# Altium schematic sheet High Voltage.SchDoc("High Voltage")
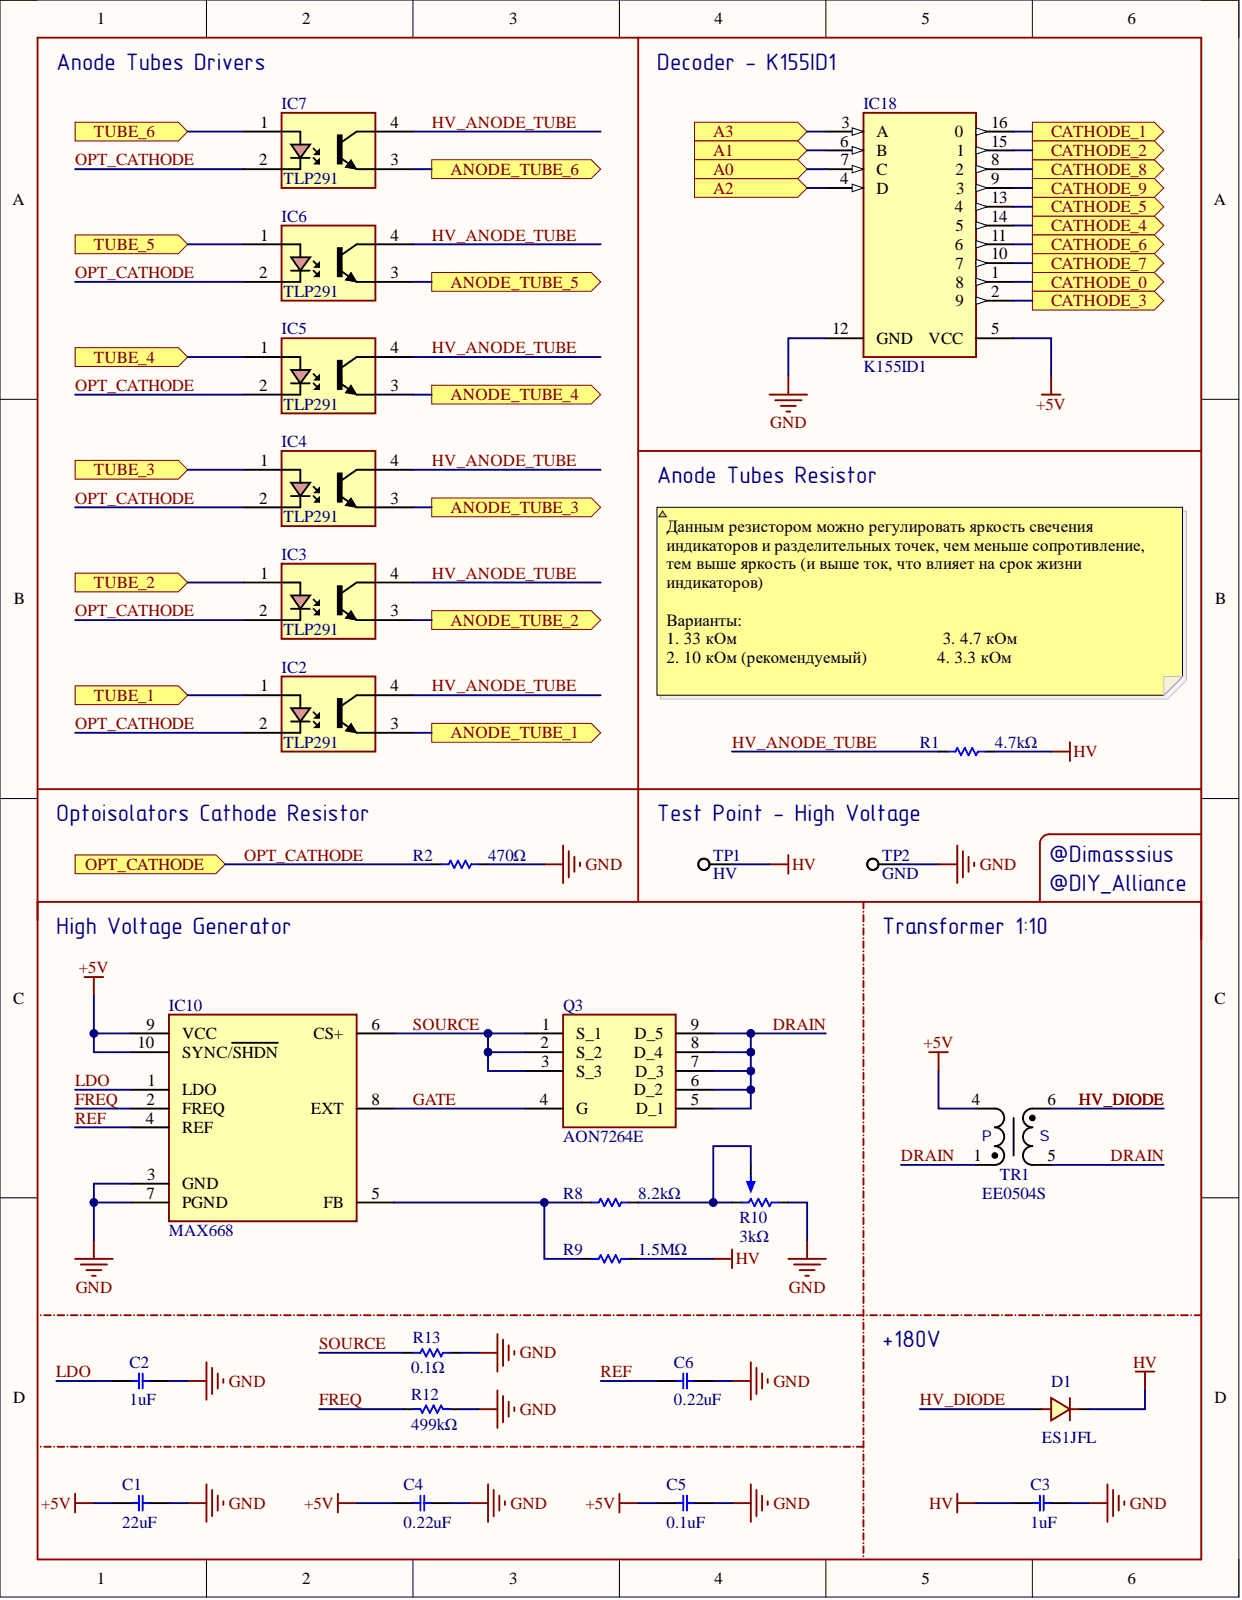
[diagram p0: High Voltage.SchDoc]

## Parts
C1  22uF  [CAP_SMD_MLCC_C0603_(M1608)_C0402A_(M1005A)]
C2  1uF  [CAP_SMD_MLCC_C0603_(M1608)_C0402A_(M1005A)]
C3  1uF  [CAP_SMD_MLCC_C1812_(M4532)]
C4  0.22uF  [CAP_SMD_MLCC_C0603_(M1608)_C0402A_(M1005A)]
C5  0.1uF  [CAP_SMD_MLCC_C0603_(M1608)_C0402A_(M1005A)]
C6  0.22uF  [CAP_SMD_MLCC_C0603_(M1608)_C0402A_(M1005A)]
D1  ES1JFL  [SODFL3719X135N]
IC2  TLP291  [SOIC127P700X230-4N]
IC3  TLP291  [SOIC127P700X230-4N]
IC4  TLP291  [SOIC127P700X230-4N]
IC5  TLP291  [SOIC127P700X230-4N]
IC6  TLP291  [SOIC127P700X230-4N]
IC7  TLP291  [SOIC127P700X230-4N]
IC10  MAX668  [SOP50P490X110-10N]
IC18  K155ID1  [DIP16]
Q3  AON7264E  [DFN-8-EP(3x3)]
R1  4.7kΩ  [RES_SMD_R0603_(M1608)_R0402A_(M1005A)]
R2  470Ω  [RES_SMD_R0603_(M1608)_R0402A_(M1005A)]
R8  8.2kΩ  [RES_SMD_R0603_(M1608)_R0402A_(M1005A)]
R9  1.5MΩ  [RES_SMD_R0603_(M1608)_R0402A_(M1005A)]
R10  3kΩ  [TC33X]
R12  499kΩ  [RES_SMD_R0603_(M1608)_R0402A_(M1005A)]
R13  0.1Ω  [RES_SMD_R1206_(M3216)]
TP1  HV  [Test Point]  (no BOM)
TP2  GND  [Test Point]  (no BOM)
TR1  EE0504S  [EE0504S]

## Ports
A0, A1, A2, A3, ANODE_TUBE_1, ANODE_TUBE_2, ANODE_TUBE_3, ANODE_TUBE_4, ANODE_TUBE_5, ANODE_TUBE_6, CATHODE_0, CATHODE_1, CATHODE_2, CATHODE_3, CATHODE_4, CATHODE_5, CATHODE_6, CATHODE_7, CATHODE_8, CATHODE_9, OPT_CATHODE, TUBE_1, TUBE_2, TUBE_3, TUBE_4, TUBE_5, TUBE_6

## Nets
+5V: C1.1 C4.2 C5.1 IC10.9(VCC) IC10.10(SYNC/SHDN) IC18.5(VCC) TR1.4
GND: C1.2 C2.2 C3.1 C4.1 C5.2 C6.1 IC10.3(GND) IC10.7(PGND) IC18.12(GND) R2.1 R10.3 R12.2 R13.1 TP2.1
HV: C3.2 D1.1 R1.2 R9.1 TP1.1
A0: IC18.7(C) ; port A0
A1: IC18.6(B) ; port A1
A2: IC18.4(D) ; port A2
A3: IC18.3(A) ; port A3
ANODE_TUBE_1: IC2.3 ; port ANODE_TUBE_1
ANODE_TUBE_2: IC3.3 ; port ANODE_TUBE_2
ANODE_TUBE_3: IC4.3 ; port ANODE_TUBE_3
ANODE_TUBE_4: IC5.3 ; port ANODE_TUBE_4
ANODE_TUBE_5: IC6.3 ; port ANODE_TUBE_5
ANODE_TUBE_6: IC7.3 ; port ANODE_TUBE_6
CATHODE_0: IC18.1 ; port CATHODE_0
CATHODE_1: IC18.16 ; port CATHODE_1
CATHODE_2: IC18.15 ; port CATHODE_2
CATHODE_3: IC18.2 ; port CATHODE_3
CATHODE_4: IC18.14 ; port CATHODE_4
CATHODE_5: IC18.13 ; port CATHODE_5
CATHODE_6: IC18.11 ; port CATHODE_6
CATHODE_7: IC18.10 ; port CATHODE_7
CATHODE_8: IC18.8 ; port CATHODE_8
CATHODE_9: IC18.9 ; port CATHODE_9
DRAIN: Q3.5(D_1) Q3.6(D_2) Q3.7(D_3) Q3.8(D_4) Q3.9(D_5) TR1.1 TR1.5
FREQ: IC10.2(FREQ) R12.1
GATE: IC10.8(EXT) Q3.4(G)
HV_ANODE_TUBE: IC2.4 IC3.4 IC4.4 IC5.4 IC6.4 IC7.4 R1.1
HV_DIODE: D1.2 TR1.6
LDO: C2.1 IC10.1(LDO)
OPT_CATHODE: IC2.2 IC3.2 IC4.2 IC5.2 IC6.2 IC7.2 R2.2 ; port OPT_CATHODE
REF: C6.2 IC10.4(REF)
SOURCE: IC10.6(CS+) Q3.1(S_1) Q3.2(S_2) Q3.3(S_3) R13.2
TUBE_1: IC2.1 ; port TUBE_1
TUBE_2: IC3.1 ; port TUBE_2
TUBE_3: IC4.1 ; port TUBE_3
TUBE_4: IC5.1 ; port TUBE_4
TUBE_5: IC6.1 ; port TUBE_5
TUBE_6: IC7.1 ; port TUBE_6
NetIC10_5: IC10.5(FB) R8.2 R9.2
NetR8_1: R8.1 R10.1 R10.2

## Library data of the parts
C1 = CAP_SMD_MLCC_22UF_6.3V: Capacitor, Ceramic (MLCC), SMD, 22uF, 6.3V, ±20%, X5R, Multilayer Ceramic Capacitors MLCC - SMD/SMT, ROHS; Manufacturer: Murata Electronics North America; Part Number: GRM31CR60J226KE19L; @ qty: 0; Minimum Quantity: 1; Tolerance: ±10%; Unit Price (USD): 0.35; Applications: General Purpose; Component Kind: Standard; Datasheets: http://www.murata.com/~/media/webrenewal/support/library/catalog/products/capacitor/mlcc/c02e.pdf; Digi-Key Part Number: 490-1824-1-ND; Factory Stock: 0; Features: -; Height - Seated (Max): -; Image: //media.digikey.com/Renders/Murata%20Renders/GR331BD72E473KW01L.jpg; Lead Spacing: -; Lead Style: -; Library Name: Capacitors.SchLib; Mounting Type: Surface Mount, MLCC; Operating Temperature: -55°C ~ 85°C; Package / Case: -; Packaging: Cut Tape (CT); Part Status: Active; Quantity Available: 42035; Ratings: -; Series: GRM; Size / Dimension: -; Temperature Coefficient: X5R; Thickness (Max): -; Value: 22uF; Voltage: 6.3V
C2 = CAP_SMD_MLCC_1UF_6.3V: Capacitor, Ceramic (MLCC), SMD, 1uF, 6.3V, ±10%, X5R, Multilayer Ceramic Capacitors MLCC - SMD/SMT, ROHS; Manufacturer: Murata Electronics North America; Part Number: GRM319R61A105KA01D; @ qty: 0; Minimum Quantity: 1; Unit Price (USD): 0.28; Voltage: 10V; Applications: General Purpose; Component Kind: Standard; Datasheets: http://www.murata.com/~/media/webrenewal/support/library/catalog/products/capacitor/mlcc/c02e.pdf; Digi-Key Part Number: 490-11607-1-ND; Factory Stock: 0; Features: -; Height - Seated (Max): -; Image: //media.digikey.com/Renders/Murata%20Renders/GR331BD72E473KW01L.jpg; Lead Spacing: -; Lead Style: -; Library Name: Capacitors.SchLib; Mounting Type: Surface Mount, MLCC; Operating Temperature: -55°C ~ 85°C; Package / Case: -; Packaging: Cut Tape (CT); Part Status: Active; Quantity Available: 3593; Ratings: -; Series: GRM; Size / Dimension: -; Temperature Coefficient: X5R; Thickness (Max): -; Tolerance: ±10%; Value: 1uF
C3 = CAP_SMD_MLCC_1UF_250V: Capacitor, Ceramic (MLCC), SMD, 1uF, 250V, ±10%, X7R, Multilayer Ceramic Capacitors MLCC - SMD/SMT, ROHS; Manufacturer: Murata Electronics North America; Part Number: GRM319R61A105KA01D; @ qty: 0; Minimum Quantity: 1; Temperature Coefficient: X5R; Unit Price (USD): 0.28; Voltage: 10V; Applications: General Purpose; Component Kind: Standard; Datasheets: http://www.murata.com/~/media/webrenewal/support/library/catalog/products/capacitor/mlcc/c02e.pdf; Digi-Key Part Number: 490-11607-1-ND; Factory Stock: 0; Features: -; Height - Seated (Max): -; Image: //media.digikey.com/Renders/Murata%20Renders/GR331BD72E473KW01L.jpg; Lead Spacing: -; Lead Style: -; Library Name: Capacitors.SchLib; Mounting Type: Surface Mount, MLCC; Operating Temperature: -55°C ~ 85°C; Package / Case: -; Packaging: Cut Tape (CT); Part Status: Active; Quantity Available: 3593; Ratings: -; Series: GRM; Size / Dimension: -; Thickness (Max): -; Tolerance: ±10%; Value: 1uF
C4 C6 = CAP_SMD_MLCC_0.22UF_6.3V: Capacitor, Ceramic (MLCC), SMD, 220nF, 6.3V, ±10%, X7R, Multilayer Ceramic Capacitors MLCC - SMD/SMT, ROHS; Manufacturer: Murata Electronics North America; Part Number: GRM319R71C224KA01D; @ qty: 0; Minimum Quantity: 1; Unit Price (USD): 0.26; Voltage: 16V; Applications: General Purpose; Component Kind: Standard; Datasheets: http://www.murata.com/~/media/webrenewal/support/library/catalog/products/capacitor/mlcc/c02e.pdf; Digi-Key Part Number: 490-11608-1-ND; Factory Stock: 0; Features: -; Height - Seated (Max): -; Image: //media.digikey.com/Renders/Murata%20Renders/GR331BD72E473KW01L.jpg; Lead Spacing: -; Lead Style: -; Library Name: Capacitors.SchLib; Mounting Type: Surface Mount, MLCC; Operating Temperature: -55°C ~ 125°C; Package / Case: -; Packaging: Cut Tape (CT); Part Status: Active; Quantity Available: 10161; Ratings: -; Series: GRM; Size / Dimension: -; Temperature Coefficient: X7R; Thickness (Max): -; Tolerance: ±10%; Value: 0.22uF
C5 = CAP_SMD_MLCC_0.1UF_6.3V: Capacitor, Ceramic (MLCC), SMD, 100nF, 6.3V, ±10%, X7R, Multilayer Ceramic Capacitors MLCC - SMD/SMT, ROHS; Manufacturer: Murata Electronics North America; Part Number: LLM315R71C104MA11L; @ qty: 0; Minimum Quantity: 1; Tolerance: ±20%; Unit Price (USD): 0.9; Voltage: 16V; Applications: Bypass, Decoupling; Component Kind: Standard; Datasheets: http://www.murata.com/~/media/webrenewal/support/library/catalog/products/capacitor/mlcc/c02e.pdf; Digi-Key Part Number: 490-4495-1-ND; Factory Stock: 0; Features: Low ESL (Multi-Terminal); Height - Seated (Max): -; Image: //media.digikey.com/Renders/Murata%20Renders/1206(LLM315).jpg; Lead Spacing: -; Lead Style: -; Library Name: Capacitors.SchLib; Mounting Type: Surface Mount, MLCC; Operating Temperature: -55°C ~ 125°C; Package / Case: -; Packaging: Cut Tape (CT); Part Status: Active; Quantity Available: 2915; Ratings: -; Series: LLM; Size / Dimension: -; Temperature Coefficient: X7R; Thickness (Max): -; Value: 0.1uF
D1 = ES1JFL: Diode, SMD, SOD-123F, 600V, 1A, 22.55ns, 1.7V@1A, Independent Type,Fast Recovery / High Efficiency Diodes, ROHS; Manufacturer_Name: Taiwan Semiconductor; Manufacturer_Part_Number: ES1JFLRVG; Component Kind: Standard; Datasheet Link: https://www.mouser.in/datasheet/2/395/ES1DFL_SERIES_C1812-1918087.pdf; Height: 1.35mm; Library Name: SamacSys.SchLib; Value: ES1JFL
IC2-IC7 = TLP291: Photovoltaic Output Optoisolator, SOP-4-1.27mm, 80V, 3.75kV, 50mA, 300mV@2.4mA, 8mA, 1, 5V, 1.25V, DC  Transistor, Photovoltaic Output Optoisolators, ROHS; Component Kind: Standard; Datasheet Link: https://datasheet.datasheetarchive.com/originals/distributors/Datasheets-TOS/DSATOSJO10001087.pdf; Height: 2.3mm; Library Name: SamacSys.SchLib; Value: TLP291
IC10 = MAX668EUB: AC-DC Controller and Regulator, MSOP-10, 100kHz~500kHz, 3V~28V, AC-DC Controllers and Regulators, ROHS; Component Kind: Standard; Datasheet Link: http://datasheets.maximintegrated.com/en/ds/MAX668-MAX669.pdf; Height: 1.1mm; Library Name: SamacSys.SchLib; Value: MAX668
IC18 = K155ID1: Decoder, DIP-16, 5V±5%, 25mA, 150ns, High Voltage Output, BCD to Decimal Decoders, RoHS; Component Kind: Standard; Datasheet Link: http://pdf1.alldatasheet.com/datasheet-pdf/view/115763/TI/SN74141.html; Height: 5.08mm; Library Name: SamacSys.SchLib; Value: K155ID1
Q3 = AON7264E: MOSFET (N-Channel), DFN-8(3x3), 60V, 28A, 11W, 13.3mΩ@4.5V, 28A, 1.8V, 1 N-channel, MOSFETs, ROHS; Component Kind: Standard; Library Name: SamacSys.SchLib; Value: AON7264E
R1 = RES_SMD_4.7KΩ: Resistor, Thick Film Resistor, SMD, 4.7kΩ, ±5%, Chip Resistor - Surface Mount, ROHS; Component Kind: Standard; Library Name: Resistors.SchLib; Value: 4.7kΩ
R2 = RES_SMD_470Ω: Resistor, Thick Film Resistor, SMD, 470Ω, ±5%, Chip Resistor - Surface Mount, ROHS; Component Kind: Standard; Library Name: Resistors.SchLib; Value: 470Ω
R8 = RES_SMD_8.2KΩ: Resistor, Thick Film Resistor, SMD, 8.2kΩ, ±5%, Chip Resistor - Surface Mount, ROHS; Component Kind: Standard; Library Name: Resistors.SchLib; Value: 8.2kΩ
R9 = RES_SMD_1.5MΩ: Resistor, Thick Film Resistor, SMD, 1.5MΩ, ±5%, Chip Resistor - Surface Mount, ROHS; Component Kind: Standard; Library Name: Resistors.SchLib; Value: 1.5MΩ
R10 = RES_SMD_POT_3KΩ: Resistor, Potentiometer, SMD, SMD-3P, 3.9x3.1mm, Potentiometer, 3kΩ, ±25%, 150mW, Potentiometers, Variable Resistors, ROHS; Component Kind: Standard; Library Name: Resistors.SchLib; Value: 3kΩ
R12 = RES_SMD_499KΩ: Resistor, Thick Film Resistor, SMD, 499kΩ, ±5%, Chip Resistor - Surface Mount, ROHS; Component Kind: Standard; Library Name: Resistors.SchLib; Value: 499kΩ
R13 = RES_SMD_0.1Ω: Resistor, Thick Film Resistor, SMD, 0.1Ω, ±5%, Chip Resistor - Surface Mount, ROHS; Component Kind: Standard; Library Name: Resistors.SchLib; Value: 0.1Ω
TP1 TP2 = Test Point: Description: Test Point; Library Name: SamacSys.SchLib
  TP1: Value: HV
  TP2: Value: GND
TR1 = EE0504S: Power Transformer, SMD-5P, 1:10, 6.4x6.4mm, Power Transformers, ROHS; Component Kind: Standard; Library Name: SamacSys.SchLib; Value: EE0504S
